# Altium schematic sheet CPU_DIN_mainboard_power.SchDoc(CPU_DIN_mainboard_power)
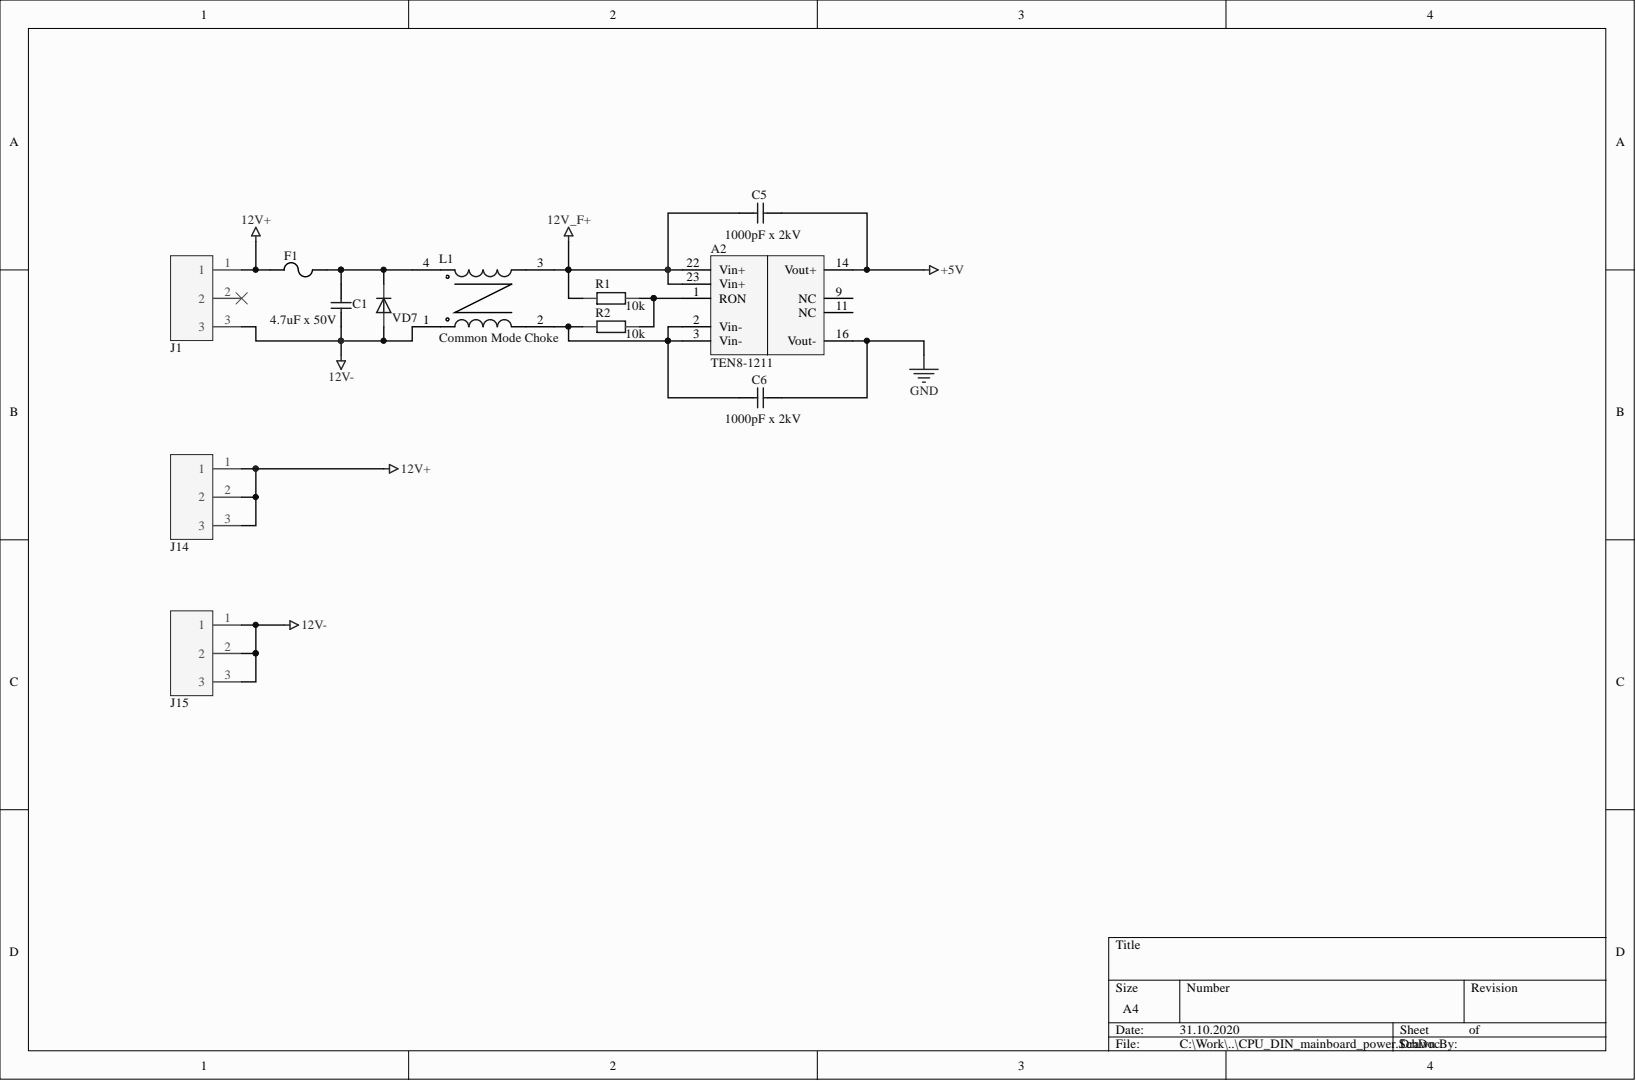
[diagram p0: CPU_DIN_mainboard_power.SchDoc]

## Parts
A2  TEN8-1211  [dcdc_ten8-1211]
C1  4.7uF x 50V  [C1206]
C5  1000pF x 2kV  [c1206]
C6  1000pF x 2kV  [c1206]
F1  FUSE  [MF-SM100]  (value not drawn)
J1  Terminal block TB-3  [TB-3_ser370]  (value not drawn)
J14  Terminal block TB-3  [TB-3_ser370]  (value not drawn)
J15  Terminal block TB-3  [TB-3_ser370]  (value not drawn)
L1  Common Mode Choke  [TCK-050]
R1  10k  [R0805]
R2  10k  [R0805]
VD7  15MQ040N  [SMA DIOD]  (value not drawn)

## Nets
12V+: F1.1 J1.1 J14.1 J14.2 J14.3
12V-: C1.2 J1.3 J15.1 J15.2 J15.3 L1.1 VD7.A
12V_F+: A2.22(Vin+) A2.23(Vin+) C5.1 L1.3 R1.2
+5V: A2.14(Vout+) C5.2
GND: A2.16(Vout-) C6.2
NetA2_1: A2.1(RON) R1.1 R2.1
NetA2_2: A2.2(Vin-) A2.3(Vin-) C6.1 L1.2 R2.2
NetC1_1: C1.1 F1.2 L1.4 VD7.K

Not connected: A2 9(NC) 11(NC); J1 2

## Library data of the parts
A2 = TEN8-1211: Traco Isolated DC/DC; partnum: TEN8-1211; Library Name: Lib_001.SchLib; Component Kind: Standard
C1 = CAP_SMD: partnum: GRM31CR71H475KA12L; value: 4.7uF x 50V; Library Name: Lib_001.SchLib; Component Kind: Standard
C5 C6 = CAP_SMD: value: 1000pF x 2kV; Library Name: Lib_001.SchLib; Component Kind: Standard
F1 = FUSE: partnum: MF-SM100-2; Library Name: Lib_001.SchLib; Component Kind: Standard
J1 J14 J15 = TB-3: Library Name: Lib_001.SchLib; Component Kind: Standard
L1 = L_CHOKE: partnum: TCK-050; Library Name: Lib_001.SchLib; Description: Common Mode Choke; Component Kind: Standard
R1 R2 = RES_SMD: value: 10k; Simulation: Model Name; Library Name: Lib_001.SchLib; Component Kind: Standard
VD7 = DIOD: partnum: VS-15MQ040NTRPBF; value: 15MQ040N; Library Name: Lib_001.SchLib; Component Kind: Standard
